# Altium schematic sheet Logic_Level_Converter.SchDoc
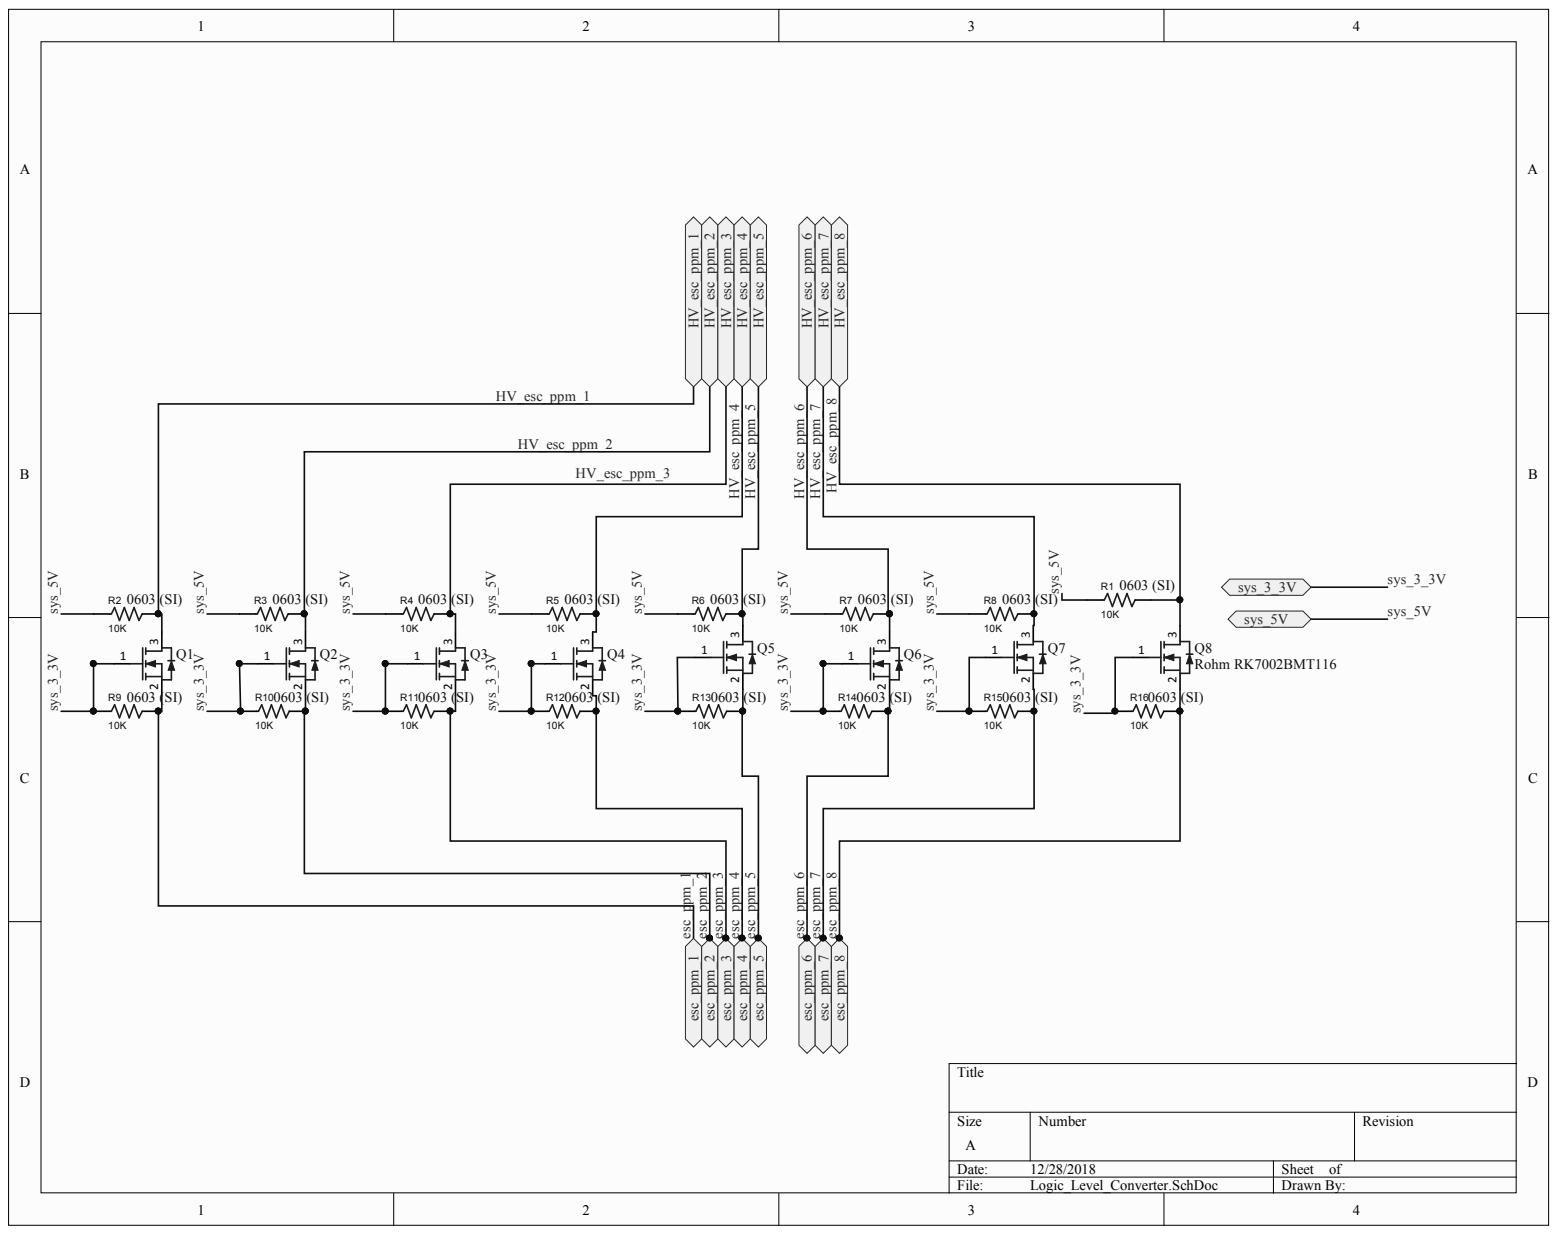
[diagram p0: Logic_Level_Converter.SchDoc]

## Parts
Q1  Rohm RK7002BMT116  [PCB-lhick5qix2l1q5xknwuk-1]
Q2  Rohm RK7002BMT116  [PCB-lhick5qix2l1q5xknwuk-1]
Q3  Rohm RK7002BMT116  [PCB-lhick5qix2l1q5xknwuk-1]
Q4  Rohm RK7002BMT116  [PCB-lhick5qix2l1q5xknwuk-1]
Q5  Rohm RK7002BMT116  [PCB-lhick5qix2l1q5xknwuk-1]
Q6  Rohm RK7002BMT116  [PCB-lhick5qix2l1q5xknwuk-1]
Q7  Rohm RK7002BMT116  [PCB-lhick5qix2l1q5xknwuk-1]
Q8  Rohm RK7002BMT116  [PCB-lhick5qix2l1q5xknwuk-1]
R1  10K  Case/Package: 0603 (SI)  [R0201]
R2  10K  Case/Package: 0603 (SI)  [R0201]
R3  10K  Case/Package: 0603 (SI)  [R0201]
R4  10K  Case/Package: 0603 (SI)  [R0201]
R5  10K  Case/Package: 0603 (SI)  [R0201]
R6  10K  Case/Package: 0603 (SI)  [R0201]
R7  10K  Case/Package: 0603 (SI)  [R0201]
R8  10K  Case/Package: 0603 (SI)  [R0201]
R9  10K  Case/Package: 0603 (SI)  [R0201]
R10  10K  Case/Package: 0603 (SI)  [R0201]
R11  10K  Case/Package: 0603 (SI)  [R0201]
R12  10K  Case/Package: 0603 (SI)  [R0201]
R13  10K  Case/Package: 0603 (SI)  [R0201]
R14  10K  Case/Package: 0603 (SI)  [R0201]
R15  10K  Case/Package: 0603 (SI)  [R0201]
R16  10K  Case/Package: 0603 (SI)  [R0201]

## Ports
ESC_PPM_1, ESC_PPM_2, ESC_PPM_3, ESC_PPM_4, ESC_PPM_5, ESC_PPM_6, ESC_PPM_7, ESC_PPM_8, HV_ESC_PPM_1, HV_ESC_PPM_2, HV_ESC_PPM_3, HV_ESC_PPM_4, HV_ESC_PPM_5, HV_ESC_PPM_6, HV_ESC_PPM_7, HV_ESC_PPM_8, SYS_3_3V, SYS_5V

## Nets
ESC_PPM_1: Q1.2 R9.2 ; port ESC_PPM_1
ESC_PPM_2: Q2.2 R10.2 ; port ESC_PPM_2
ESC_PPM_3: Q3.2 R11.2 ; port ESC_PPM_3
ESC_PPM_4: Q4.2 R12.2 ; port ESC_PPM_4
ESC_PPM_5: Q5.2 R13.2 ; port ESC_PPM_5
ESC_PPM_6: Q6.2 R14.2 ; port ESC_PPM_6
ESC_PPM_7: Q7.2 R15.2 ; port ESC_PPM_7
ESC_PPM_8: Q8.2 R16.2 ; port ESC_PPM_8
HV_ESC_PPM_1: Q1.3 R2.2 ; port HV_ESC_PPM_1
HV_ESC_PPM_2: Q2.3 R3.2 ; port HV_ESC_PPM_2
HV_ESC_PPM_3: Q3.3 R4.2 ; port HV_ESC_PPM_3
HV_ESC_PPM_4: Q4.3 R5.2 ; port HV_ESC_PPM_4
HV_ESC_PPM_5: Q5.3 R6.2 ; port HV_ESC_PPM_5
HV_ESC_PPM_6: Q6.3 R7.2 ; port HV_ESC_PPM_6
HV_ESC_PPM_7: Q7.3 R8.2 ; port HV_ESC_PPM_7
HV_ESC_PPM_8: Q8.3 R1.2 ; port HV_ESC_PPM_8
SYS_3_3V: Q1.1 Q2.1 Q3.1 Q4.1 Q5.1 Q6.1 Q7.1 Q8.1 R9.1 R10.1 R11.1 R12.1 R13.1 R14.1 R15.1 R16.1 ; port SYS_3_3V
SYS_5V: R1.1 R2.1 R3.1 R4.1 R5.1 R6.1 R7.1 R8.1 ; port SYS_5V

## Library data of the parts
Q1-Q8 = CMP-c6120e73f56f19d5-3: N-Channel 60 V 0.2 W Surface Mount 2.5 V Drive Silicon Power Mosfet - SOT-23; Component Kind: Standard; Library Name: CommunityVault; Mounting Style: Surface Mount; Octopart URL: http://octopart.com/rk7002bmt116-rohm-23795227
R1-R16 = CMP-8c48478736f5538f-2: Surface Mount Chip Resistor, Thick Film, RC Series, 33 ohm, 50 mW, 1%, 0201 [0603 Metric]; Component Kind: Standard; Library Name: CommunityVault
